# Altium schematic sheet [15] PWR.SchDoc("[15] PWR")
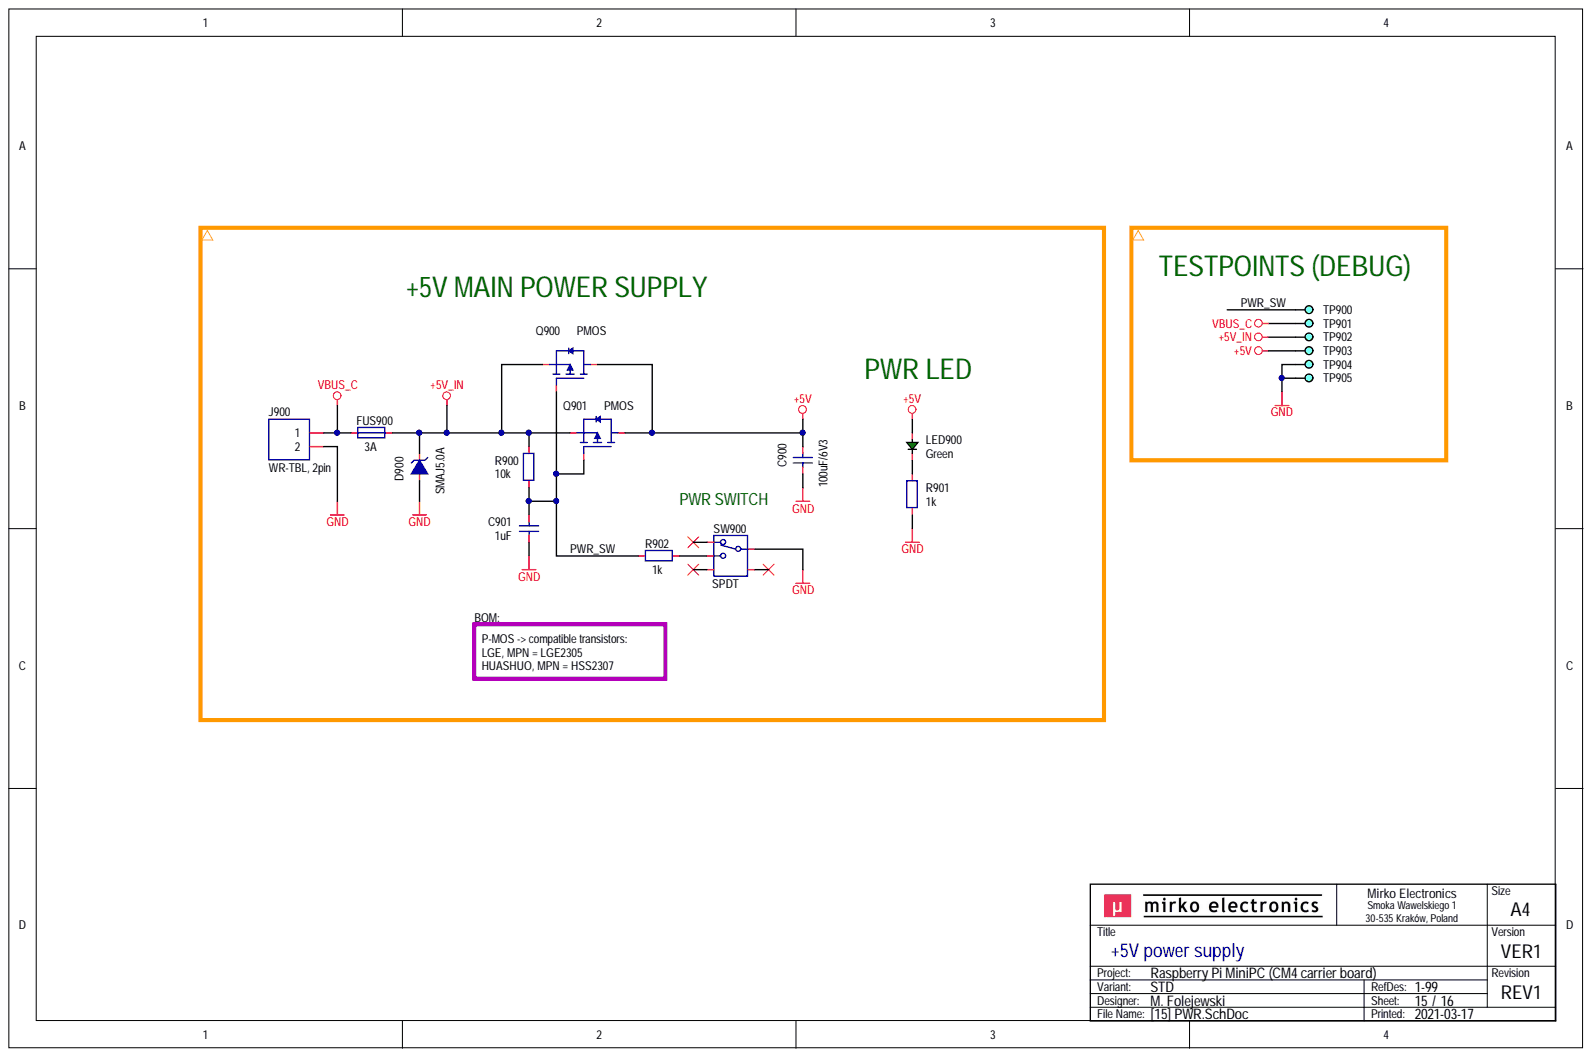
[diagram p0: [15] PWR.SchDoc]

## Parts
C900  100uF/6V3  [CAP_1206_R]
C901  1uF  [CAP_0603_R]
D900  SMAJ5.0A  [DIO_SMA_UNI_R]
FUS900  3A  [FUS_1812_PTC_R]
J900  WR-TBL, 2pin  [CON_WRT_691305140002]
LED900  Green  [LED_0805_GRN_R]
Q900  PMOS  [PKG_SOT23-3_TO236]
Q901  PMOS  [PKG_SOT23-3_TO236]
R900  10k  [RES_0603_R]
R901  1k  [RES_0603_R]
R902  1k  [RES_0603_R]
SW900  SPDT
TP900  DEBUG  [PCB_TP_DBG_50]  (no BOM)
TP901  DEBUG  [PCB_TP_DBG_50]  (value not drawn)  (no BOM)
TP902  DEBUG  [PCB_TP_DBG_50]  (value not drawn)  (no BOM)
TP903  DEBUG  [PCB_TP_DBG_50]  (value not drawn)  (no BOM)
TP904  DEBUG  [PCB_TP_DBG_50]  (value not drawn)  (no BOM)
TP905  DEBUG  [PCB_TP_DBG_50]  (value not drawn)  (no BOM)

## Nets
+5V: C900.2 LED900.2 Q900.3 Q901.3 TP903.1
+5V_IN: D900.1 FUS900.2 Q900.2 Q901.2 R900.2 TP902.1
GND: C900.1 C901.1 D900.2 J900.2 R901.2 SW900.2 TP904.1 TP905.1
VBUS_C: FUS900.1 J900.1 TP901.1
PWR_SW: C901.2 Q900.1 Q901.1 R900.1 R902.1 TP900.1
NetLED900_1: LED900.1 R901.1
NetR902_2: R902.2 SW900.3

Not connected: SW900 1 SH SH1

## Library data of the parts
C900 = CAP-0025: Capacitor; MLCC; GENERIC; TBD; TBD; TBD; TBD; SMD; 1206 (3216);; Database Table Name: CAPACITOR$; Voltage Rating: TBD; Value: 100uF/6V3; Tolerance: TBD; ROHS Status: TBD; Packaging Type: TBD; Package (Case): 1206 (3216); Operating Temperature: TBD; MPN: GENERIC; Mounting Type: SMD; Manufacturer: GENERIC; ID: CAP-0025; Features: TBD; Dielectric: TBD; Component Type: Capacitor; Component Subtype: MLCC; Component Kind: Standard; Component Height: TBD; Component Body: TBD; Capacitance: GENERIC; Library Name: MirFol_db_local.DbLib
C901 = CAP-0009: Capacitor; MLCC; 1uF; TBD; TBD; TBD; TBD; SMD; 0603 (1608);; Database Table Name: CAPACITOR$; Voltage Rating: TBD; Value: 1uF; Tolerance: TBD; ROHS Status: TBD; Packaging Type: TBD; Package (Case): 0603 (1608); Operating Temperature: TBD; MPN: TBD; Mounting Type: SMD; Manufacturer: TBD; ID: CAP-0009; Features: TBD; Dielectric: TBD; Component Type: Capacitor; Component Subtype: MLCC; Component Kind: Standard; Component Height: TBD; Component Body: TBD; Capacitance: 1uF; Library Name: MirFol_db_local.DbLib
D900 = DIO_TVS_UNI_KA: Diode; Schottky; Unipolar;; Manufacturer: Littelfuse; Value: SMAJ5.0A; MPN: SMAJ5.0A; Component Kind: Standard; Library Name: MirFol_lib.SchLib
FUS900 = FUS: Fuse; MPN: 1812L150ZR; Manufacturer: Littelfuse; Value: 3A; Component Kind: Standard; Library Name: MirFol_lib.SchLib
J900 = CON_1X02_COL: Connector; 1 Row;; MPN: 691 305 140 002; Manufacturer: Wurth Elektronik; Value: WR-TBL, 2pin; Component Kind: Standard; Library Name: MirFol_lib.SchLib
LED900 = DIO_LED_GRN: Diode; LED; Green;; Value: Green; Component Kind: Standard; Library Name: MirFol_lib.SchLib
Q900 Q901 = TRA_PMOS_GSD: Transistor; PMOS;; MPN: LGE2305; Manufacturer: LGE; Value: PMOS; Component Kind: Standard; Library Name: MirFol_lib.SchLib
R900 = RES-0028: Resistor; Thick Film; 10k; 1%; 100mW; 100PPM; 75V; Standard; SMD; 0603 (1608);; MPN: RC0603FR-0710KL; Manufacturer: Yageo Corporation; Packaging Type: Tape & Reel; Database Table Name: RESISTOR$; Component Body: 1.60 x 0.80mm; Voltage Rating: 75V; Value: 10k; Tolerance: 1%; TCR: 100PPM; ROHS Status: RoHS Compliant; Resistance: 10k; Power Rating: 100mW; Package (Case): 0603 (1608); Operating Temperature: -55°C to +155°C; Mounting Type: SMD; ID: RES-0028; Features: Standard; Component Type: Resistor; Component Subtype: Thick Film; Component Kind: Standard; Component Height: 0.45mm; Library Name: MirFol_db_local.DbLib
R901 R902 = RES-0027: Resistor; Thick Film; 1k; 1%; 100mW; 100PPM; 75V; Standard; SMD; 0603 (1608);; MPN: TBD; Manufacturer: Yageo Corporation; Packaging Type: Tape & Reel; Database Table Name: RESISTOR$; Component Body: 1.60 x 0.80mm; Voltage Rating: 75V; Value: 1k; Tolerance: 1%; TCR: 100PPM; ROHS Status: RoHS Compliant; Resistance: 1k; Power Rating: 100mW; Package (Case): 0603 (1608); Operating Temperature: -55°C to +155°C; Mounting Type: SMD; ID: RES-0027; Features: Standard; Component Type: Resistor; Component Subtype: Thick Film; Component Kind: Standard; Component Height: 0.45mm; Library Name: MirFol_db_local.DbLib
SW900 = SWI_SPDT_3P_SH: Switch; SPDT;; MPN: OS102011MA1QN1; Manufacturer: C&K Switches; Value: SPDT; Component Kind: Standard; Library Name: MirFol_lib.SchLib
TP900-TP905 = PCB_TP_DBG: PCB Marking; Test Point (Debug);; Value: DEBUG; Library Name: MirFol_lib.SchLib
